# Altium schematic sheet lv_comm.SchDoc
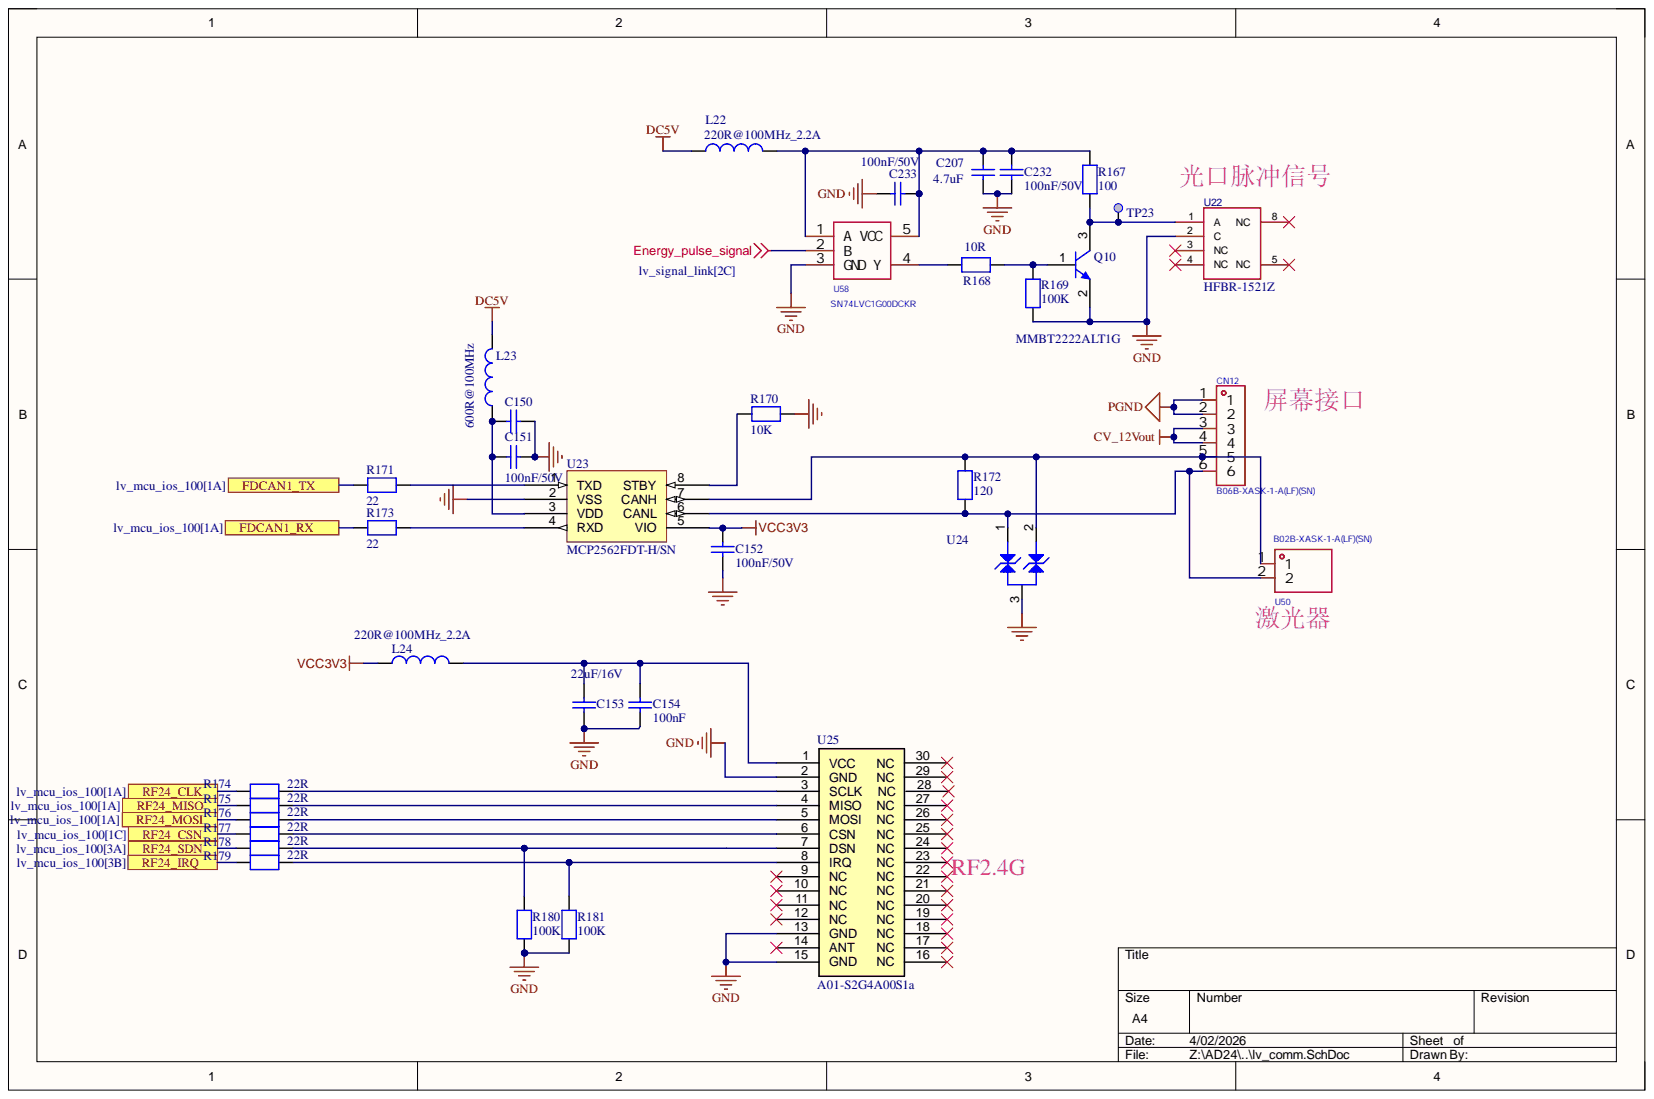
[diagram p0: lv_comm.SchDoc]

## Parts
C150  1uF  [C0603]  (value not drawn)
C151  100nF/50V  [C0402]
C152  100nF/50V  [C0402]
C153  22uF/16V  [C1206]
C154  100nF  [C0402]
C207  4.7uF  [C0603]
C232  100nF/50V  [C0402]
C233  100nF/50V  [C0402]
CN12  B06B-XASK-1-A(LF)(SN)  [CONN-TH_B06B-XASK-1-A-LF-SN]
L22  220R@100MHz_2.2A  [L0603]
L23  600R@100MHz  [L0603]
L24  220R@100MHz_2.2A  [L0603]
Q10  MMBT2222ALT1G  [NPN_SOT23]
R167  100  [0805]
R168  10R  [R0402]
R169  100K  [R0402]
R170  10K  [R0402]
R171  22  [R0402]
R172  120  [R0603]
R173  22  [R0402]
R174  22R  [R0402]
R175  22R  [R0402]
R176  22R  [R0402]
R177  22R  [R0402]
R178  22R  [R0402]
R179  22R  [R0402]
R180  100K  [R0402]
R181  100K  [R0402]
TP23  Test Point  [TP_SMD_1MM]  (value not drawn)  (no BOM)
U22  HFBR-1521Z  [CONN-TH_HFBR-1521Z]
U23  MCP2562FD  value MCP2562FDT-H/SN  Manufacturer_Part_Number: MCP2562FDT-H/SN  [ICC_SO8_5X4_P127]
U24  ESDCAN03-2BWY  [DIO_SOT323]  (value not drawn)
U25  A01-S2G4A00S1a  [S24-2020.4.1]
U50  B02B-XASK-1-A(LF)(SN)  [CONN-TH_B02B-XASK-1-A-LF-SN]
U58  SN74LVC1G00DCKR  [SOT-23-5_L3.0-W1.7-P0.95-LS2.8-BR]

## Ports
FDCAN1_RX, FDCAN1_TX, RF24_CLK, RF24_CSN, RF24_IRQ, RF24_MISO, RF24_MOSI, RF24_SDN

## Nets
CV_12Vout: CN12.3 CN12.4
DC5V: L22.2 L23.2
Energy_pulse_signal: U58.2(B)
GND: C150.2 C151.2 C152.2 C153.1 C154.2 C207.1 C232.2 C233.2 Q10.2 R169.1 R170.2 R180.1 R181.1 U22.2(C) U23.2(VSS) U24.3 U25.2(GND) U25.13(GND) U25.15(GND) U58.3(GND)
PGND: CN12.1 CN12.2
VCC3V3: C152.1 L24.2 U23.5(VIO)
FDCAN1_RX: R173.1 ; port FDCAN1_RX
FDCAN1_TX: R171.1 ; port FDCAN1_TX
RF24_CLK: R174.1 ; port RF24_CLK
RF24_CSN: R177.1 ; port RF24_CSN
RF24_IRQ: R179.1 ; port RF24_IRQ
RF24_MISO: R175.1 ; port RF24_MISO
RF24_MOSI: R176.1 ; port RF24_MOSI
RF24_SDN: R178.1 ; port RF24_SDN
NetC150_1: C150.1 C151.1 L23.1 U23.3(VDD)
NetC153_2: C153.2 C154.1 L24.1 U25.1(VCC)
NetC207_2: C207.2 C232.1 C233.1 L22.1 R167.2 U58.1(A) U58.5(VCC)
NetCN12_5: CN12.5 R172.1 U23.7(CANH) U24.2 U50.1
NetCN12_6: CN12.6 R172.2 U23.6(CANL) U24.1 U50.2
NetQ10_1: Q10.1 R168.2 R169.2
NetQ10_3: Q10.3 R167.1 TP23.1 U22.1(A)
NetR168_1: R168.1 U58.4(Y)
NetR170_1: R170.1 U23.8(STBY)
NetR171_2: R171.2 U23.1(TXD)
NetR173_2: R173.2 U23.4(RXD)
NetR174_2: R174.2 U25.3(SCLK)
NetR175_2: R175.2 U25.4(MISO)
NetR176_2: R176.2 U25.5(MOSI)
NetR177_2: R177.2 U25.6(CSN)
NetR178_2: R178.2 R180.2 U25.7(DSN)
NetR179_2: R179.2 R181.2 U25.8(IRQ)

Not connected: U22 3(NC) 4(NC) 5(NC) 8(NC); U25 9(NC) 10(NC) 11(NC) 12(NC) 14(ANT) 16(NC) 17(NC) 18(NC) 19(NC) 20(NC) 21(NC) 22(NC) 23(NC) 24(NC) 25(NC) 26(NC) 27(NC) 28(NC) 29(NC) 30(NC)

## Library data of the parts
C150 = MCD-00010: Capacitor, MLCC, 1uF, 16V, X5R, 10%, 0603, T=0,8mm; Manufacturer: YAGEO; Manufacturer_Part_Number: CC0603KRX5R7BB105; Database Table Name: MCD_PARTS$; Initial_Board_Reference: MB1274; Provided_By: Subcon; Component Kind: Standard; ComponentLink1Description: Yageo_Capacitor_X5R-series.pdf; ComponentLink1URL: http://tunmcdsvn.tun.st.com/Component_Datasheet_Library/Trunk/Capacitors/Yageo_Multilayer_capacitor_X5R_series_100pF_to_100uF; ECCN_EU: n/a; ECCN_US: n/a; Internal_Part_Number: MCD-00010; Library Name: MCD_PARTS_database.DbLib; Package: C0603; Part_Type: Capacitor; Status: Released
C151 C152 = MCD-00006: 100nF, 50V, X7R, 10%; Manufacturer: YAGEO; Manufacturer_Part_Number: GRM155R71H104KE14D; Database Table Name: MCD_PARTS$; Component Kind: Standard; ComponentLink1Description: Datasheet; ComponentLink1URL: http://tunmcdsvn.tun.st.com/Component_Datasheet_Library/Trunk/Capacitors/Yageo_Multilayer_Capacitor_X7R_series_100pF_to_22uF; Library Name: MCD_PARTS_database.DbLib
C153 = Capacitor_1: 16V, X5R, 10%; Manufacturer: muRata; Manufacturer_Part_Number: GRM31CR61C226KE15L; Component Kind: Standard; Library Name: HiPin_Library_AD.IntLib
C154 = Capacitor: 50V, 10%, X7R; Internal_Part_Number: MCD-00003; Manufacturer: muRata; Manufacturer_Part_Number: GRM155R71H104KE14D; Component Kind: Standard; ComponentLink1Description: Datasheet; ComponentLink1URL: http://tunmcdsvn.tun.st.com/Component_Datasheet_Library/Trunk/Capacitors/Yageo_Capacitor_NP0_16V-to-50V; Library Name: HiPin_Library_AD.IntLib; Package: C0402; Value: 100nF
C207 = Capacitor_1: 16V, X7S, 10%; Internal_Part_Number: MCD-00009; Manufacturer: muRata; Manufacturer_Part_Number: GRM188C71C475KE21D; Component Kind: Standard; ComponentLink1Description: Yageo_Capacitor_X5R-series.pdf; ComponentLink1URL: http://tunmcdsvn.tun.st.com/Component_Datasheet_Library/Trunk/Capacitors/Yageo_Multilayer_capacitor_X5R_series_100pF_to_100uF; Library Name: HiPin_Library_AD.IntLib; Package: C0603; Value: 4.7uF
C232 C233 = Capacitor_2: 50V, X7R , 10%; Manufacturer: muRata; Manufacturer_Part_Number: GRM155R71H104KE14D; Component Kind: Standard; ComponentLink1Description: Datasheet; ComponentLink1URL: http://tunmcdsvn.tun.st.com/Component_Datasheet_Library/Trunk/Capacitors/Yageo_Multilayer_capacitor_X5R_series_100pF_to_100uF; Library Name: HiPin_Library_AD.IntLib
CN12 = B06B-XASK-1-A(LF)(SN): 插座XA2.5mm,3A,H8.8mm; Manufacturer: JST; Add into BOM: yes; Flame Retardant Rating: UL94V-0; Number of PINs Per Row: 6; Pins Structure: 1x6P; Pitch: 2.5mm; Plastic Material: 玻纤增强PA66; Rated Voltage (Max): 250V; Reference Series: XA; Supplementary Features: 打K脚；带柱; X-Length of Bottom Edge on Board (Spacing Line): 17.5mm; Y-Width of Bottom Edge on Board: 6.4mm; Z-Height of the Board: 8.8mm; Colour: 白色; Component Kind: Standard; Contact Material: 铜合金; Contact Plating: 锡; Convert to PCB: yes; Current Rating (Max): 3A; Datasheet: https://atta.szlcsc.com/upload/public/pdf/source/20220509/C25BFBA8A7816C529B22589B590C7AEA.pdf; Device: B06B-XASK-1-A(LF)(SN); JLCPCB Part Class: Extended Part; LCSC Part Name: 1x6P 间距:2.5mm 直插 系列:XA; Library Name: HiPin_Library_AD.IntLib; Manufacturer Part: B06B-XASK-1-A(LF)(SN); Mounting Style: 直插; Number of Pins: 6P; Number of Rows: 1; Operating Temperature Range: -25℃~+85℃; PCB3D: CONN-TH_B06B-XASK-1-A-LF-SN; Row Spacing: -; Supplier: LCSC; Supplier Footprint: 插件,P=2.5mm; Supplier Part: C491711; Symbol: B06B-XASK-1-A(LF)(SN)
L22 L24 = MCD-00047: SMD Chip ferrite bead, 220R@100MHz +/-25%,2.2A, 50mohm; Manufacturer: Sunlord; Manufacturer_Part_Number: UPZ1608U221-2R2TF; Database Table Name: MCD_PARTS$; Component Kind: Standard; ComponentLink1Description: Datasheet; ComponentLink1URL: http://tunmcdsvn.tun.st.com/Component_Datasheet_Library/Trunk/Inductors/TaiTech_Bead_FCM1608_series; Internal_Part_Number: MCD-00047; Library Name: MCD_PARTS_database.DbLib
L23 = MCD-00047: +/-25%, 350mA, 500mohm DC; Manufacturer_Part_Number: FCM1608KF-601T03; Database Table Name: MCD_PARTS$; Component Kind: Standard; ComponentLink1Description: Datasheet; ComponentLink1URL: http://tunmcdsvn.tun.st.com/Component_Datasheet_Library/Trunk/Inductors/TaiTech_Bead_FCM1608_series; Library Name: MCD_PARTS_database.DbLib
Q10 = 9013-SOT23: NPN Bipolar Transistor; Alternate_Manufacturer: ON SEMICONDUCTOR; Alternate_Manufacturer_Part_Number: MMBT2222LT1G / MMBT2222ALT1G / MMBT2222ALT3G; Internal_Part_Number: MCD-00073; Manufacturer_Part_Number: BSR14; Part_Type: IC; ECCN_EU: NEC; ECCN_US: EAR99; Provided_By: SUBCO; Component Kind: Standard; ComponentLink1Description: Datasheet; ComponentLink1URL: http://tunmcdsvn.tun.st.com/Component_Datasheet_Library/Trunk/IC/NXP_Transistor_BSR14; Library Name: HiPin_Library_AD.IntLib; Manufacturer: ON SEMICONDUCTOR; Package: SOT23; Status: Released
R167-R169 R171-R181 = RES: 1%; Value: 10K; Component Kind: Standard; Library Name: HiPin_Library_AD.IntLib
R170 = RES: 1%; Component Kind: Standard; Library Name: HiPin_Library_AD.IntLib; Value: 10K
TP23 = MCD-00074: Test Point 1mm; Part_Type: Mechanical; Database Table Name: MCD_PARTS$; ComponentLink1Description: Datasheet; ComponentLink1URL: http://na; ECCN_EU: n/a; ECCN_US: n/a; Internal_Part_Number: MCD-00074; Library Name: MCD_PARTS_database.DbLib; Manufacturer: n/a; Manufacturer_Part_Number: n/a; Provided_By: n/a; Status: Released
U22 = HFBR-1521Z: 光纤发射器; Component Kind: Standard; Library Name: HiPin_Library_AD.IntLib; Symbol: HFBR-1521Z
U23 = MCD-00129: High-Speed CAN Flexible Data Rate Transceiver; Manufacturer: MICROCHIP; Part_Type: IC; Database Table Name: MCD_PARTS$; Initial_Board_Reference: MB1262; Item Type: Item; Path: ws/MCD_ApplicationBoards/Lists/MCDPARTSdatabase; Provided_By: SUBCO; Component Kind: Standard; ComponentLink1Description: Datasheet; ComponentLink1URL: http://tunmcdsvn.tun.st.com/Component_Datasheet_Library/Trunk/IC/Microchip_CAN Transceiver_MCP2562FD; Internal_Part_Number: MCD-00129; Library Name: MCD_PARTS_database.DbLib; Status: Released
U24 = MCD-01228: 极性  双向  反向截止电压(Vrwm)  24V  钳位电压  41V  峰值脉冲电流(Ipp)  3.7A@8/20us; Manufacturer: STMICROELECTRONICS; Database Table Name: MCD_PARTS$; Component Kind: Standard; Library Name: MCD_PARTS_database.DbLib; Manufacturer_Part_Number: ESDCAN03-2BWY
U25 = A01-S2G4A00S1a: RF2.4G; Component Kind: Standard; Library Name: HiPin_Library_AD.IntLib
U50 = B02B-XASK-1-A(LF)(SN): XA插座，间距: 2.5mm，直插，H8.8mm; Manufacturer: JST; Add into BOM: yes; Current Rating (Max): 3A; Flame Retardant Rating: UL94V-0; Number of PINs Per Row: 2; Pins Structure: 1x2P; Pitch: 2.5mm; Plastic Material: 玻纤增强PA66; Rated Voltage (Max): 250V; Reference Series: XA; Supplementary Features: 带柱；打K脚; X-Length of Bottom Edge on Board (Spacing Line): 7.5mm; Y-Width of Bottom Edge on Board: 6.4mm; Z-Height of the Board: 8.8mm; Colour: 白色; Component Kind: Standard; Contact Material: 铜合金; Contact Plating: 锡; Convert to PCB: yes; Datasheet: https://atta.szlcsc.com/upload/public/pdf/source/20180829/C265077_AACBE43BFB9344D794AFC81CB60287FF.pdf; Device: B02B-XASK-1-A(LF)(SN); JLCPCB Part Class: Extended Part; LCSC Part Name: 1x2P 间距:2.5mm 直插 系列:XA; Library Name: HiPin_Library_AD.IntLib; Manufacturer Part: B02B-XASK-1-A(LF)(SN); Mounting Style: 直插; Number of Pins: 2P; Number of Rows: 1; Operating Temperature Range: -25℃~+85℃; PCB3D: CONN-TH_B02B-XASK-1-A-LF-SN; Row Spacing: -; Supplier: LCSC; Supplier Footprint: 插件,P=2.5mm; Supplier Part: C265077; Symbol: B02B-XASK-1-A(LF)(SN)
U58 = SN74LVC1G08DBVR: 与非门; Component Kind: Standard; Library Name: HiPin_Library_AD.IntLib; PCB3D: SOT-23-5_L2.9-W1.6-H1.1-LS2.8-P0.95
